# Altium schematic sheet Connectors.SchDoc(Connectors)
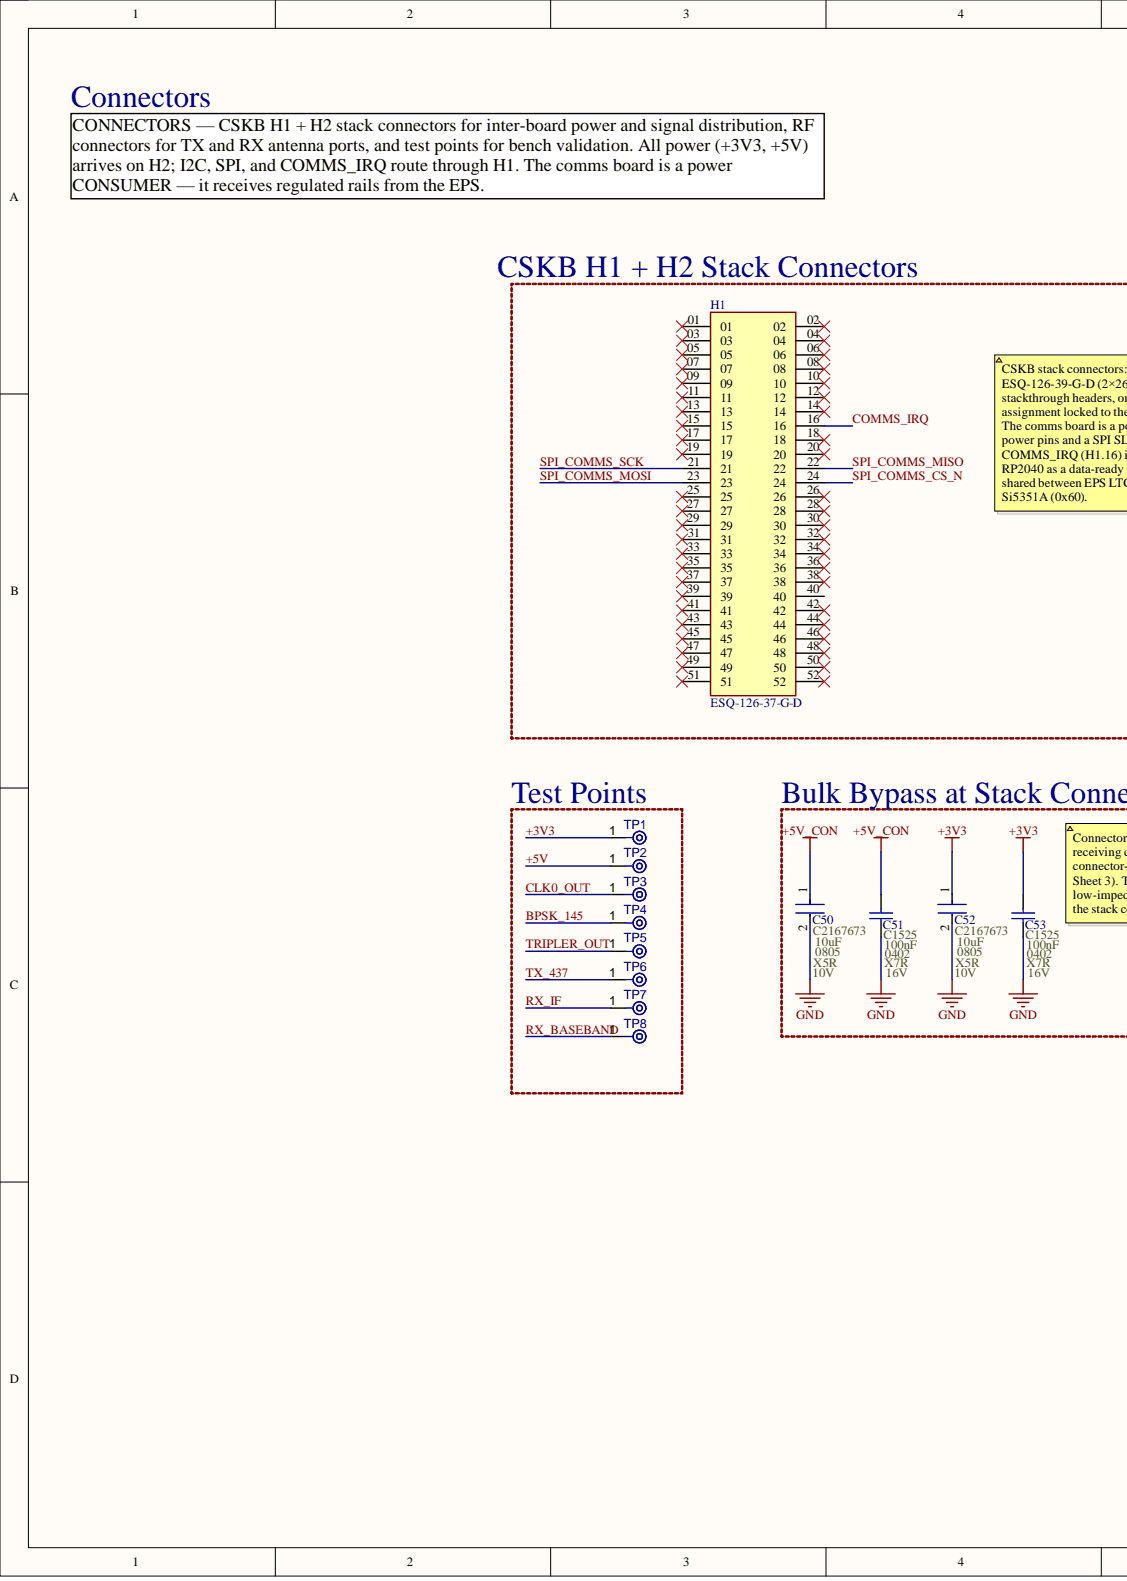
[diagram p0: Connectors.SchDoc, left]
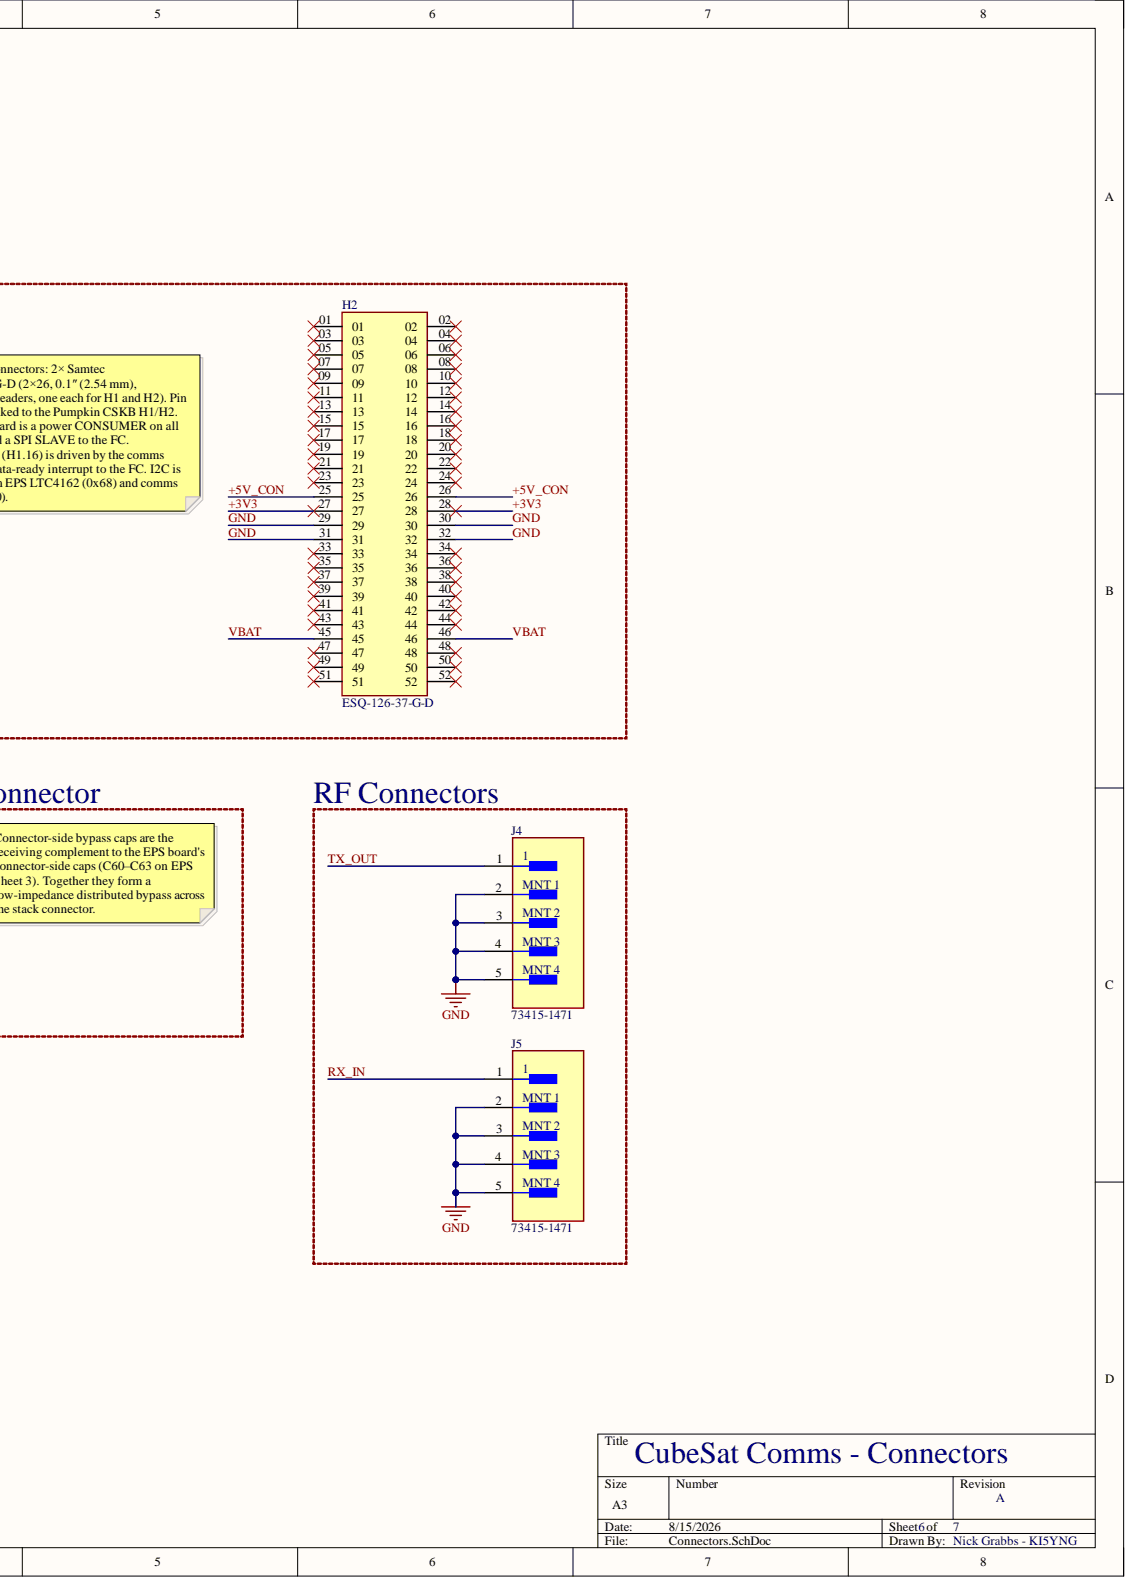
[diagram p1: Connectors.SchDoc, right]

## Parts
C50  value 10uF  Capacitance: 10uF  LCSC Part #: C2167673  Package: 0805  Temperature Characteristic: X5R  (hidden comment: C0805C106K8PACTU)
C51  Capacitance: 100nF  Case Size/Dimension: 0402  Dielectric: X7R  LCSC Part #: C1525  Rated Voltage: 16V  (hidden comment: CL05B104KO5NNNC)
C52  value 10uF  Capacitance: 10uF  LCSC Part #: C2167673  Package: 0805  Temperature Characteristic: X5R  (hidden comment: C0805C106K8PACTU)
C53  Capacitance: 100nF  Case Size/Dimension: 0402  Dielectric: X7R  LCSC Part #: C1525  Rated Voltage: 16V  (hidden comment: CL05B104KO5NNNC)
H1  ESQ-126-37-G-D
H2  ESQ-126-37-G-D
J4  73415-1471  Device Class L1: Connectors
J5  73415-1471
TP1  TPAD6R  (value not drawn)  (no BOM)
TP2  TPAD6R  (value not drawn)  (no BOM)
TP3  TPAD6R  (value not drawn)  (no BOM)
TP4  TPAD6R  (value not drawn)  (no BOM)
TP5  TPAD6R  (value not drawn)  (no BOM)
TP6  TPAD6R  (value not drawn)  (no BOM)
TP7  TPAD6R  (value not drawn)  (no BOM)
TP8  TPAD6R  (value not drawn)  (no BOM)

## Nets
+3V3: C52.1 C53.1 H2.27 H2.28 TP1.1
+5V: TP2.1
+5V_CON: C50.1 C51.1 H2.25 H2.26
BPSK_145: TP4.1
CLK0_OUT: TP3.1
COMMS_IRQ: H1.16
GND: C50.2 C51.2 C52.2 C53.2 H2.29 H2.30 H2.31 H2.32 J4.2 J4.3 J4.4 J4.5 J5.2 J5.3 J5.4 J5.5
RX_BASEBAND: TP8.1
RX_IF: TP7.1
RX_IN: J5.1
SPI_COMMS_CS_N: H1.24
SPI_COMMS_MISO: H1.22
SPI_COMMS_MOSI: H1.23
SPI_COMMS_SCK: H1.21
TRIPLER_OUT: TP5.1
TX_437: TP6.1
TX_OUT: J4.1
VBAT: H2.45 H2.46

Not connected: H1 01 02 03 04 05 06 07 08 09 10 11 12 13 14 15 17 18 19 20 25 26 27 28 29 30 31 32 33 34 35 36 37 38 39 40 41 42 43 44 45 46 47 48 49 50 51 52; H2 01 02 03 04 05 06 07 08 09 10 11 12 13 14 15 16 17 18 19 20 21 22 23 24 33 34 35 36 37 38 39 40 41 42 43 44 47 48 49 50 51 52

## Library data of the parts
C50 C52 = CMP-2007-03243-2: CAP CER 10UF 10V X5R 0805; 3DS Part Number: 220-00001; Manufacturer: Kemet; Material: Ceramic; Temperature Coefficient: 15%; Tolerance: 10%; Automotive: No; Category: Cap; Component Kind: Standard; ComponentLink2Description: Datasheet; ComponentLink2URL: https://content.kemet.com/datasheets/KEM_C1006_X5R_SMD.pdf; Device Class L1: Passive Components; Device Class L2: Capacitors; Device Class L3: Ceramic Capacitors; Digikey Description: CAP CER 10UF 10V X5R 0805; Height: 1.4mm; Ipc Land Pattern Name: CAPC200125X125; Lead Free: Yes; Library Name: Altium Content Vault; Manufacturer Part Number: C0805C106K8PACTU; Mouser Description: CAP CER 10UF 10V X5R 0805; Octopart: https://octopart.com/c0805c106k8pactu-kemet-98697; RoHS: TRUE; Temperature: -55°C to +85°C; Temperature Range High: +85°C; Temperature Range Low: -55°C; Voltage: 10V; Voltage Rating: 10V
C51 C53 = CMP-2000-05439-1: Chip Capacitor, 100 nF, +/- 10%, 16 V, -55 to 125 degC, 0402 (1005 Metric), RoHS, Tape and Reel; Manufacturer: Samsung Electro-Mechanics; Component Kind: Standard; Datasheet URL: https://datasheet.ciiva.com/29198/458718-da-01-en-vs-kondensator-0402-29198423.pdf; Datasheet Version: Nov-10; Library Name: Altium Content Vault; Manufacturer URL: http://www.samsungsem.com/global/index.jsp; Tolerance (%): ±10%
H1 H2 = CMP-015-000000-1: MF: Samtec; Availability: Unavailable; Component Kind: Standard; Component Type: Standard; Design Item ID: ESQ-126-37-G-D; MP: ESQ-126-37-G-D; Package: None; Pin Count: 52; Price: None; Source: ESQ-126-37-G-D.SchLib; Library Name: Nick Grabbs
J4 J5 = CMP-04764-000011-1: CONN MMCX JACK STR 50 OHM PCB; Manufacturer: Molex; Conn Orientation: Straight; Dielectric Material: TEFLON; Frequency: 6GHz; Impedance: 50Ω; Number Of Contacts: 1; Pitch: 2.54mm; Shielding: true; Voltage Rating: 170V; Automotive: No; Category: Conn; Component Kind: Standard; ComponentLink2Description: Datasheet; ComponentLink2URL: https://www.molex.com/webdocs/datasheets/pdf/en-us/0734151471_RF_COAX_CONNECTORS.pdf; Contact Material: Gold; Device Class L2: RF / Coaxial Connectors; Device Class L3: unset; Digikey Description: CONN MMCX JACK STR 50 OHM PCB; Footprint Url: https://www.molex.com/pdm_docs/sd/734151471_sd.pdf; Height: 4.55mm; Lead Free: Yes; Library Name: Altium Content Vault; Manufacturer Part Number: 73415-1471; Mouser Description: Conn.; RF/Coaxial; MMCX; Jack; Vertical; PCB; 50 Ohms; Rt. Angle; Gold Plate; Octopart: https://octopart.com/73415-1471-molex-776288; Package: 73415-1471; RoHS: TRUE
  J5: Device Class L1: Connectors
TP1-TP8 = CMP-00028-00002-2: 0.6mm round; Pins: 1; Library Name: Nick Grabbs
